# Altium schematic sheet SAM9X60_Core.SchDoc(SAM9X60_Core)
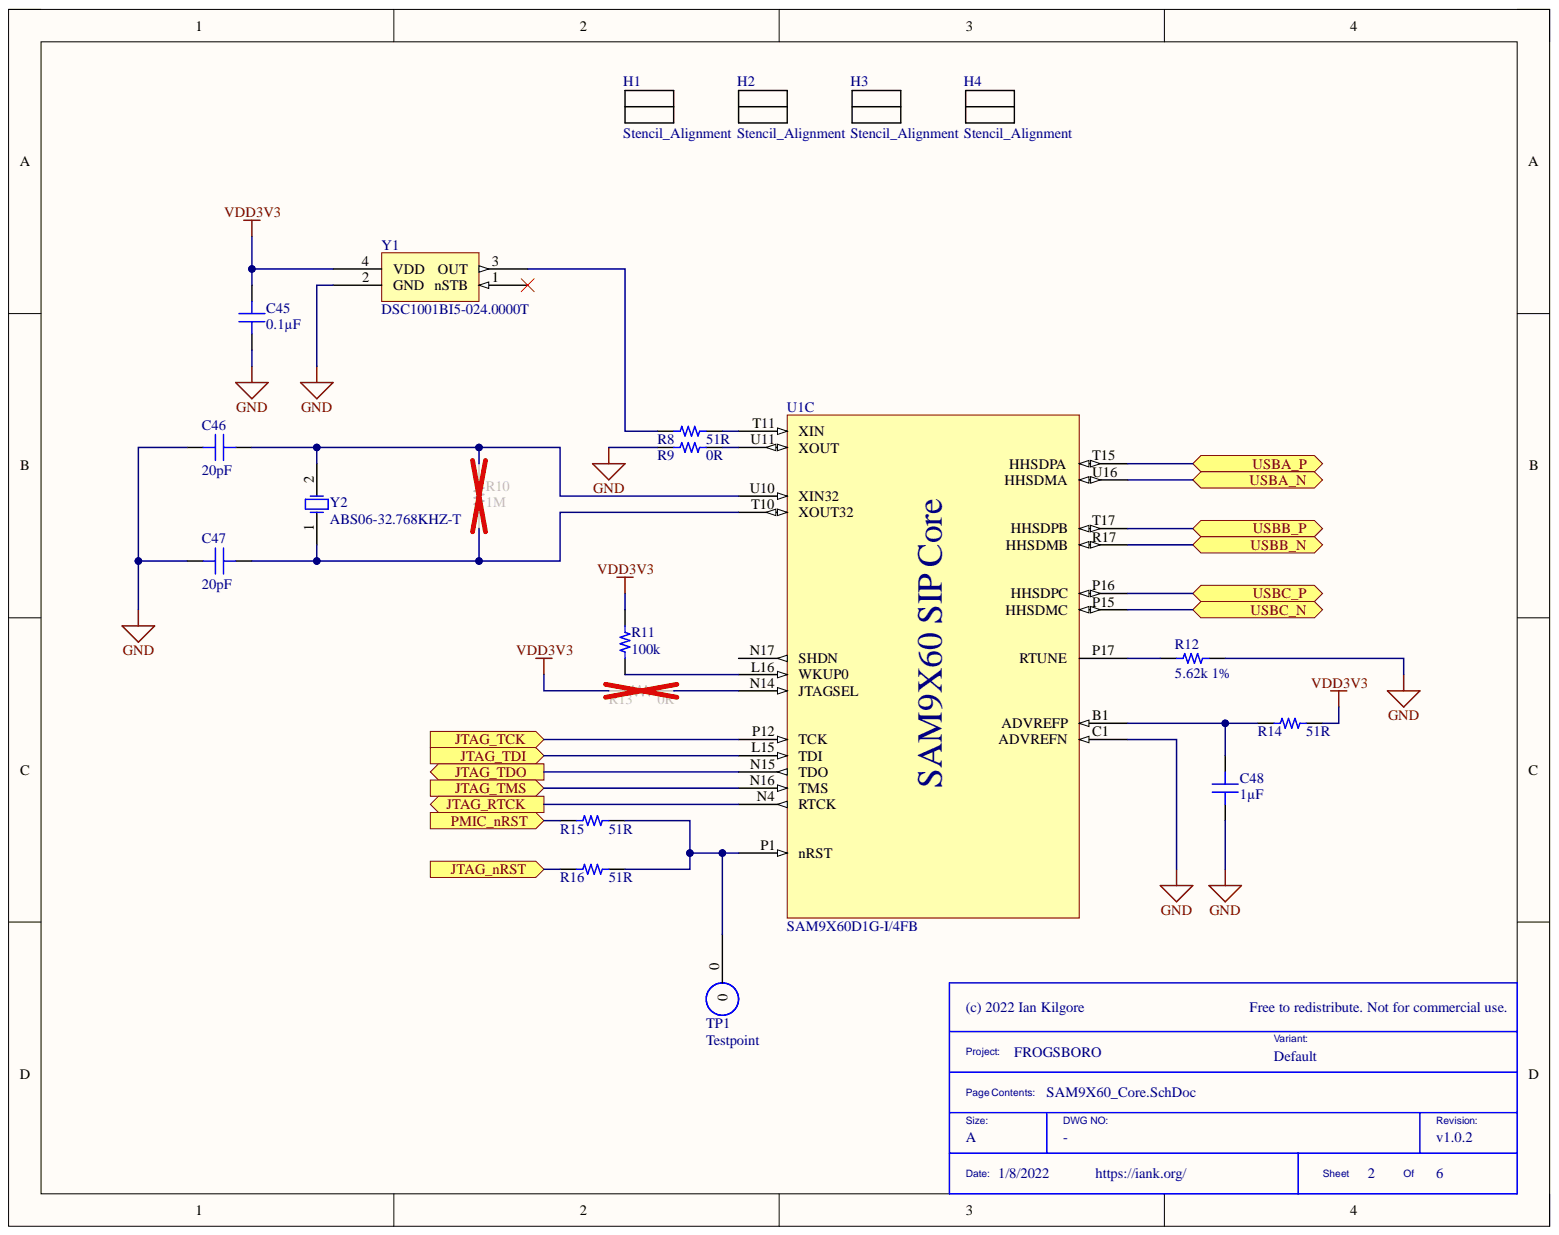
[diagram p0: SAM9X60_Core.SchDoc]

## Parts
C45  0.1µF  [CAP 0402_1005]
C46  20pF  [CAP 0402_1005]
C47  20pF  [CAP 0402_1005]
C48  1µF  [CAP 0402_1005]
H1  Stencil_Alignment  [Stencil_Alignment]
H2  Stencil_Alignment  [Stencil_Alignment]
H3  Stencil_Alignment  [Stencil_Alignment]
H4  Stencil_Alignment  [Stencil_Alignment]
R8  51R  [RES 0402_1005]
R9  0R  [RES 0402_1005]
R10  1M  [RES 0402_1005]
R11  100k  [RES 0402_1005]
R12  5.62k 1%  [RES 0402_1005]
R13  0R  [RES 0402_1005]
R14  51R  [RES 0402_1005]
R15  51R  [RES 0402_1005]
R16  51R  [RES 0402_1005]
TP1  Testpoint  [Testpoint]  (no BOM)
U1  SAM9X60D1G-I/4FB  [BGA233C80P17X17_1400X1400X120]  (part C)
Y1  DSC1001BI5-024.0000T  [DSC1001BI5]
Y2  ABS06-32.768KHZ-T  [ABRA-ABS06-2_V]

## Ports
JTAG_nRST, JTAG_RTCK, JTAG_TCK, JTAG_TDI, JTAG_TDO, JTAG_TMS, PMIC_nRST, USBA_N, USBA_P, USBB_N, USBB_P, USBC_N, USBC_P

## Nets
GND: C45.2 C46.1 C47.1 C48.1 R9.1 R12.2 U1.C1(ADVREFN) Y1.2(GND)
VDD3V3: C45.1 R11.2 R13.1 R14.2 Y1.4(VDD)
JTAG_nRST: R16.1 ; port JTAG_nRST
JTAG_RTCK: U1.N4(RTCK) ; port JTAG_RTCK
JTAG_TCK: U1.P12(TCK) ; port JTAG_TCK
JTAG_TDI: U1.L15(TDI) ; port JTAG_TDI
JTAG_TDO: U1.N15(TDO) ; port JTAG_TDO
JTAG_TMS: U1.N16(TMS) ; port JTAG_TMS
PMIC_nRST: R15.1 ; port PMIC_nRST
USBA_N: U1.U16(HHSDMA) ; port USBA_N
USBA_P: U1.T15(HHSDPA) ; port USBA_P
USBB_N: U1.R17(HHSDMB) ; port USBB_N
USBB_P: U1.T17(HHSDPB) ; port USBB_P
USBC_N: U1.P15(HHSDMC) ; port USBC_N
USBC_P: U1.P16(HHSDPC) ; port USBC_P
NetC46_2: C46.2 R10.2 U1.U10(XIN32) Y2.2
NetC47_2: C47.2 R10.1 U1.T10(XOUT32) Y2.1
NetC48_2: C48.2 R14.1 U1.B1(ADVREFP)
NetR8_1: R8.1 Y1.3(OUT)
NetR8_2: R8.2 U1.T11(XIN)
NetR9_2: R9.2 U1.U11(XOUT)
NetR11_1: R11.1 U1.L16(WKUP0)
NetR12_1: R12.1 U1.P17(RTUNE)
NetR13_2: R13.2 U1.N14(JTAGSEL)
NetR15_2: R15.2 R16.2 TP1.0 U1.P1(nRST)

Not connected: H1 0; H2 0; H3 0; H4 0; U1 N17(SHDN); Y1 1(nSTB)

## Library data of the parts
C45 = C0402C104K4PACTU: CAP CER 0.1UF 16V X5R 0402; Manufacturer: KEMET; Database Table Name: Capacitors - Ceramic - 0402; Tolerance: ±10%; Applications: General Purpose; Capacitance: 0.1µF; Component Kind: Standard; ComponentLink1Description: Datasheet; ComponentLink1URL: https://api.kemet.com/component-edge/download/datasheet/C0402C104K4PACTU.pdf; ComponentLink2Description: DigiKey Link; ComponentLink2URL: http://digikey.com/product-detail/en/kemet/C0402C104K4PACTU/399-7762-1-ND/3471485; Device Package: 0402 (1005 Metric); LastUpdated: 9/2/2020 1:02:03 AM; Library Name: Celestial Altium Library - altium_library.DbLib; Lifecycle Status: Active; Minimum Order: 1; Mounting Type: Surface Mount, MLCC; Operating Temperature: -55°C ~ 85°C; Package / Case: 0402 (1005 Metric); Packaging: TapeAndReel; Part Number: C0402C104K4PACTU; Part Status: Active; PartId: 11334; Price: 0.01; Series: SMD Comm X5R; Size / Dimension: 0.039" L x 0.020" W (1.00mm x 0.50mm); Supplier 1: DigiKey; Supplier Part Number 1: 399-7762-1-ND; Temperature Coefficient: X5R; Thickness (Max): 0.022" (0.55mm); Value: 0.1µF; Voltage - Rated: 16V
C46 C47 = GJM1555C1H200FB01D: CAP CER 20PF 50V C0G/NP0 0402; Manufacturer: Murata Electronics; Database Table Name: Capacitors - Ceramic - 0402; Temperature Coefficient: C0G, NP0; Tolerance: ±1%; Applications: RF, Microwave, High Frequency; Capacitance: 20pF; Component Kind: Standard; ComponentLink1Description: Datasheet; ComponentLink1URL: https://search.murata.co.jp/Ceramy/image/img/A01X/G101/ENG/GJM1555C1H200FB01-01.pdf; ComponentLink2Description: DigiKey Link; ComponentLink2URL: http://digikey.com/product-detail/en/murata-electronics/GJM1555C1H200FB01D/490-11204-1-ND/5333044; Device Package: 0402 (1005 Metric); Features: High Q, Low Loss; LastUpdated: 9/2/2020 1:02:17 AM; Library Name: Celestial Altium Library - altium_library.DbLib; Lifecycle Status: Active; Minimum Order: 1; Mounting Type: Surface Mount, MLCC; Operating Temperature: -55°C ~ 125°C; Package / Case: 0402 (1005 Metric); Packaging: TapeAndReel; Part Number: GJM1555C1H200FB01D; Part Status: Active; PartId: 4905; Price: 0.04; Series: GJM; Size / Dimension: 0.039" L x 0.020" W (1.00mm x 0.50mm); Supplier 1: DigiKey; Supplier Part Number 1: 490-11204-1-ND; Thickness (Max): 0.022" (0.55mm); Value: 20pF; Voltage - Rated: 50V
C48 = 0402ZD105KAT2A: CAP CER 1UF 10V X5R 0402; Manufacturer: AVX Corporation; Database Table Name: Capacitors - Ceramic - 0402; Tolerance: ±10%; Applications: General Purpose; Capacitance: 1µF; Component Kind: Standard; ComponentLink1Description: Datasheet; ComponentLink1URL: http://datasheets.avx.com/cx5r.pdf; ComponentLink2Description: DigiKey Link; ComponentLink2URL: http://digikey.com/product-detail/en/avx-corporation/0402ZD105KAT2A/478-4596-1-ND/1645907; Device Package: 0402 (1005 Metric); LastUpdated: 9/2/2020 1:02:11 AM; Library Name: Celestial Altium Library - altium_library.DbLib; Lifecycle Status: Active; Minimum Order: 1; Mounting Type: Surface Mount, MLCC; Operating Temperature: -55°C ~ 85°C; Package / Case: 0402 (1005 Metric); Packaging: TapeAndReel; Part Number: 0402ZD105KAT2A; Part Status: Active; PartId: 7596; Price: 0.03; Series: -; Size / Dimension: 0.039" L x 0.020" W (1.00mm x 0.50mm); Supplier 1: DigiKey; Supplier Part Number 1: 478-4596-1-ND; Temperature Coefficient: X5R; Thickness (Max): 0.022" (0.56mm); Value: 1µF; Voltage - Rated: 10V
R8 R14-R16 = AC0402FR-0751RL: RES SMD 51 OHM 1% 1/16W 0402; Manufacturer: Yageo; Database Table Name: Resistors - Surface Mount - 0402; Power (Watts): 0.063W, 1/16W; Resistance: 51 Ohms; Temperature Coefficient: ±100ppm/°C; Component Kind: Standard; ComponentLink1Description: Datasheet; ComponentLink1URL: https://www.yageo.com/upload/media/product/productsearch/datasheet/rchip/PYu-AC_51_RoHS_L_7.pdf; ComponentLink2Description: DigiKey Link; ComponentLink2URL: http://digikey.com/product-detail/en/yageo/AC0402FR-0751RL/YAG3500CT-ND/6006349; Composition: Thick Film; Device Package: 0402 (1005 Metric); Features: Automotive AEC-Q200, Moisture Resistant; Height - Seated (Max): 0.015" (0.37mm); LastUpdated: 9/2/2020 1:07:50 AM; Library Name: Celestial Altium Library - altium_library.DbLib; Lifecycle Status: Active; Minimum Order: 1; Number of Terminations: 2; Operating Temperature: -55°C ~ 155°C; Package / Case: 0402 (1005 Metric); Packaging: CutTape; Part Number: AC0402FR-0751RL; Part Status: Active; PartId: 12070; Price: 0.10; Ratings: AEC-Q200; Series: AC; Size / Dimension: 0.039" L x 0.020" W (1.00mm x 0.50mm); Supplier 1: DigiKey; Supplier Device Package: 0402; Supplier Part Number 1: YAG3500CT-ND; Tolerance: ±1%; Value: 51R
R9 R13 = CRG0402ZR: RES SMD 0 OHM JUMPER 1/16W 0402; Manufacturer: TE Connectivity Passive Product; Database Table Name: Resistors - Surface Mount - 0402; Power (Watts): 0.063W, 1/16W; Component Kind: Standard; ComponentLink1Description: Datasheet; ComponentLink1URL: https://www.te.com/commerce/DocumentDelivery/DDEController?Action=srchrtrv&DocNm=1773204&DocType=DS&DocLang=English; ComponentLink2Description: DigiKey Link; ComponentLink2URL: http://digikey.com/product-detail/en/te-connectivity-passive-product/CRG0402ZR/A106025CT-ND/3477649; Composition: Thick Film; Device Package: 0402 (1005 Metric); Height - Seated (Max): 0.016" (0.40mm); LastUpdated: 9/2/2020 1:07:28 AM; Library Name: Celestial Altium Library - altium_library.DbLib; Lifecycle Status: Active; Minimum Order: 1; Number of Terminations: 2; Operating Temperature: -55°C ~ 155°C; Package / Case: 0402 (1005 Metric); Packaging: CutTape; Part Number: CRG0402ZR; Part Status: Active; PartId: 20614; Price: 0.10; Series: CRG, Neohm; Size / Dimension: 0.039" L x 0.020" W (1.00mm x 0.50mm); Supplier 1: DigiKey; Supplier Device Package: 0402; Supplier Part Number 1: A106025CT-ND; Tolerance: Jumper; Value: 0R
R10 = RCS04021M00JNED: RES SMD 1M OHM 5% 1/5W 0402; Manufacturer: Vishay Dale; Database Table Name: Resistors - Surface Mount - 0402; Power (Watts): 0.2W, 1/5W; Resistance: 1 MOhms; Temperature Coefficient: ±200ppm/°C; Component Kind: Standard; ComponentLink1Description: Datasheet; ComponentLink1URL: http://www.vishay.com/docs/20065/rcse3.pdf; ComponentLink2Description: DigiKey Link; ComponentLink2URL: http://digikey.com/product-detail/en/vishay-dale/RCS04021M00JNED/541-2922-1-ND/5867215; Composition: Thick Film; Device Package: 0402 (1005 Metric); Features: Automotive AEC-Q200, Pulse Withstanding; Height - Seated (Max): 0.016" (0.40mm); LastUpdated: 9/2/2020 1:08:03 AM; Library Name: Celestial Altium Library - altium_library.DbLib; Lifecycle Status: Active; Minimum Order: 1; Number of Terminations: 2; Operating Temperature: -55°C ~ 155°C; Package / Case: 0402 (1005 Metric); Packaging: TapeAndReel; Part Number: RCS04021M00JNED; Part Status: Active; PartId: 5540; Price: 0.01; Ratings: AEC-Q200; Series: RCS e3; Size / Dimension: 0.039" L x 0.020" W (1.00mm x 0.50mm); Supplier 1: DigiKey; Supplier Device Package: 0402; Supplier Part Number 1: 541-2922-1-ND; Tolerance: ±5%; Value: 1M
R11 = CRCW0402100KFKEDC: RES SMD 100K OHM 1% 1/16W 0402; Manufacturer: Vishay Dale; Database Table Name: Resistors - Surface Mount - 0402; Power (Watts): 0.063W, 1/16W; Resistance: 100 kOhms; Temperature Coefficient: ±100ppm/°C; Component Kind: Standard; ComponentLink1Description: Datasheet; ComponentLink1URL: http://www.vishay.com/docs/28773/crcwce3.pdf; ComponentLink2Description: DigiKey Link; ComponentLink2URL: http://digikey.com/product-detail/en/vishay-dale/CRCW0402100KFKEDC/541-3960-1-ND/7928643; Composition: Thick Film; Device Package: 0402 (1005 Metric); Height - Seated (Max): 0.014" (0.35mm); LastUpdated: 9/2/2020 1:07:49 AM; Library Name: Celestial Altium Library - altium_library.DbLib; Lifecycle Status: Active; Minimum Order: 1; Number of Terminations: 2; Operating Temperature: -55°C ~ 155°C; Package / Case: 0402 (1005 Metric); Packaging: CutTape; Part Number: CRCW0402100KFKEDC; Part Status: Active; PartId: 12665; Price: 0.10; Series: CRCW-C; Size / Dimension: 0.039" L x 0.020" W (1.00mm x 0.50mm); Supplier 1: DigiKey; Supplier Device Package: 0402; Supplier Part Number 1: 541-3960-1-ND; Tolerance: ±1%; Value: 100k
R12 = AC0402FR-075K62L: RES SMD 5.62K OHM 1% 1/16W 0402; Manufacturer: Yageo; Database Table Name: Resistors - Surface Mount - 0402; Power (Watts): 0.063W, 1/16W; Resistance: 5.62 kOhms; Temperature Coefficient: ±100ppm/°C; Component Kind: Standard; ComponentLink1Description: Datasheet; ComponentLink1URL: https://www.yageo.com/upload/media/product/productsearch/datasheet/rchip/PYu-AC_51_RoHS_L_7.pdf; ComponentLink2Description: DigiKey Link; ComponentLink2URL: http://digikey.com/product-detail/en/yageo/AC0402FR-075K62L/YAG5303CT-ND/7063646; Composition: Thick Film; Device Package: 0402 (1005 Metric); Features: Automotive AEC-Q200, Moisture Resistant; Height - Seated (Max): 0.015" (0.37mm); LastUpdated: 9/2/2020 1:08:04 AM; Library Name: Celestial Altium Library - altium_library.DbLib; Lifecycle Status: Active; Minimum Order: 1; Number of Terminations: 2; Operating Temperature: -55°C ~ 155°C; Package / Case: 0402 (1005 Metric); Packaging: CutTape; Part Number: AC0402FR-075K62L; Part Status: Active; PartId: 5233; Price: 0.10; Ratings: AEC-Q200; Series: AC; Size / Dimension: 0.039" L x 0.020" W (1.00mm x 0.50mm); Supplier 1: DigiKey; Supplier Device Package: 0402; Supplier Part Number 1: YAG5303CT-ND; Tolerance: ±1%; Value: 5.62k 1%
U1 = SAM9X60D1G-I/4FB: ARM926 MPU, 1GBIT DDR2, BGA, IND TEMP; Manufacturer: Microchip; Manufacturer Part Number: SAM9X60D6K-I/4GB; Category: Microprocessors; Component Kind: Standard; Pricing 1: 1=10.63000, 25=9.74040, 100=8.82060 (USD); RoHS: No; Stock 1: 237; Supplier 1: Digi-Key; Supplier Part Number 1: 150-SAM9X60D6K-I/4GB-ND; Library Name: FROGSBORO.SchLib
Y1 = DSC1001BI5-024.0000T: Low-Power Precision CMOS Oscillator 24MHz 1.7V to 3.6V 10ppm 4-Pin Surface Mount T/R; Manufacturer: Microchip; Load Capacitance: 15pF; Max Duty Cycle: 55%; Max Supply Current: 6.3mA; Operating Supply Voltage: 3.3V; Case/Package: SMD/SMT; Category: Timers and Oscillators; Component Kind: Standard; ComponentLink1Description: http://www.mouser.com/ds/2/268/DSC1001_Datasheet-775857.pdf?src-supplier=Mouser; ComponentLink1URL: http://www.mouser.com/ds/2/268/DSC1001_Datasheet-775857.pdf?src-supplier=Mouser; ComponentLink2Description: http://www.microchip.com/mymicrochip/filehandler.aspx?ddocname=en576698&src-supplier=Digi-Key; ComponentLink2URL: http://www.microchip.com/mymicrochip/filehandler.aspx?ddocname=en576698&src-supplier=Digi-Key; Frequency: 24MHz; Frequency Stability: 10ppm; Height: 0.035inch; Length: 0.197inch; Manufacturer Part Number: DSC1001BI5-024.0000T; Max Operating Temperature: 85°C; Max Supply Voltage: 3.6V; Min Operating Temperature: -40°C; Min Supply Voltage: 1.7V; Mount: Surface Mount; Package Quantity: 1000; Packaging: Tape and Reel; Pricing 1: 1000=1.95000 (USD); Ratings: AEC-Q100; RoHS: Yes; Supplier 1: Digi-Key; Supplier Part Number 1: DSC1001BI5-024.0000T-ND; Termination: Solder; Width: 0.126inch; Library Name: FROGSBORO.SchLib
Y2 = CMP-2000-05035-1: Low Profile Crystal, 32.768 KHz, -40 to 85 degC, 2-Pin SMD, RoHS, Tape and Reel; Brand: ABRACON; Case Height: 0.6mm; Case Length: 2mm; Case Width: 1.2mm; ESR: 90kOhms; Frequency Stability: 20PPM; Load Capacitance: 12.5pF; Component Kind: Standard; Datasheet URL: http://www.mouser.com/ds/2/3/ABS06-10798.pdf; Datasheet Version: Sep-15; Dimensions: 1.2mmWx2mmLx0.6mmH; Factory Pack Quantity: 3000; Frequency: 32.768kHz; Library Name: Altium Content Vault; Manufacturer: ABRACON; Manufacturer URL: http://www.abracon.com/; Mounting Style: SMD/SMT; Operating Temperature Range: -40Cto+85C; Package / Case: 2-SMD; Package Description: 2-Pin Surface Mounted Device, Body 2 x 1.2 mm, Height 0.6 mm; Package Reference: ABS06; Packaging: Reel; Product Category: Crystals; RoHS: Details; Series: ABS06; Termination Style: SMD/SMT; Tolerance: 20PPM; Type: LowProfileCrystal
H1-H4 = Stencil_Alignment: Component Kind: Standard; Library Name: FROGSBORO.SchLib
TP1 = Testpoint: Library Name: FROGSBORO.SchLib
